# Altium schematic sheet main.SchDoc
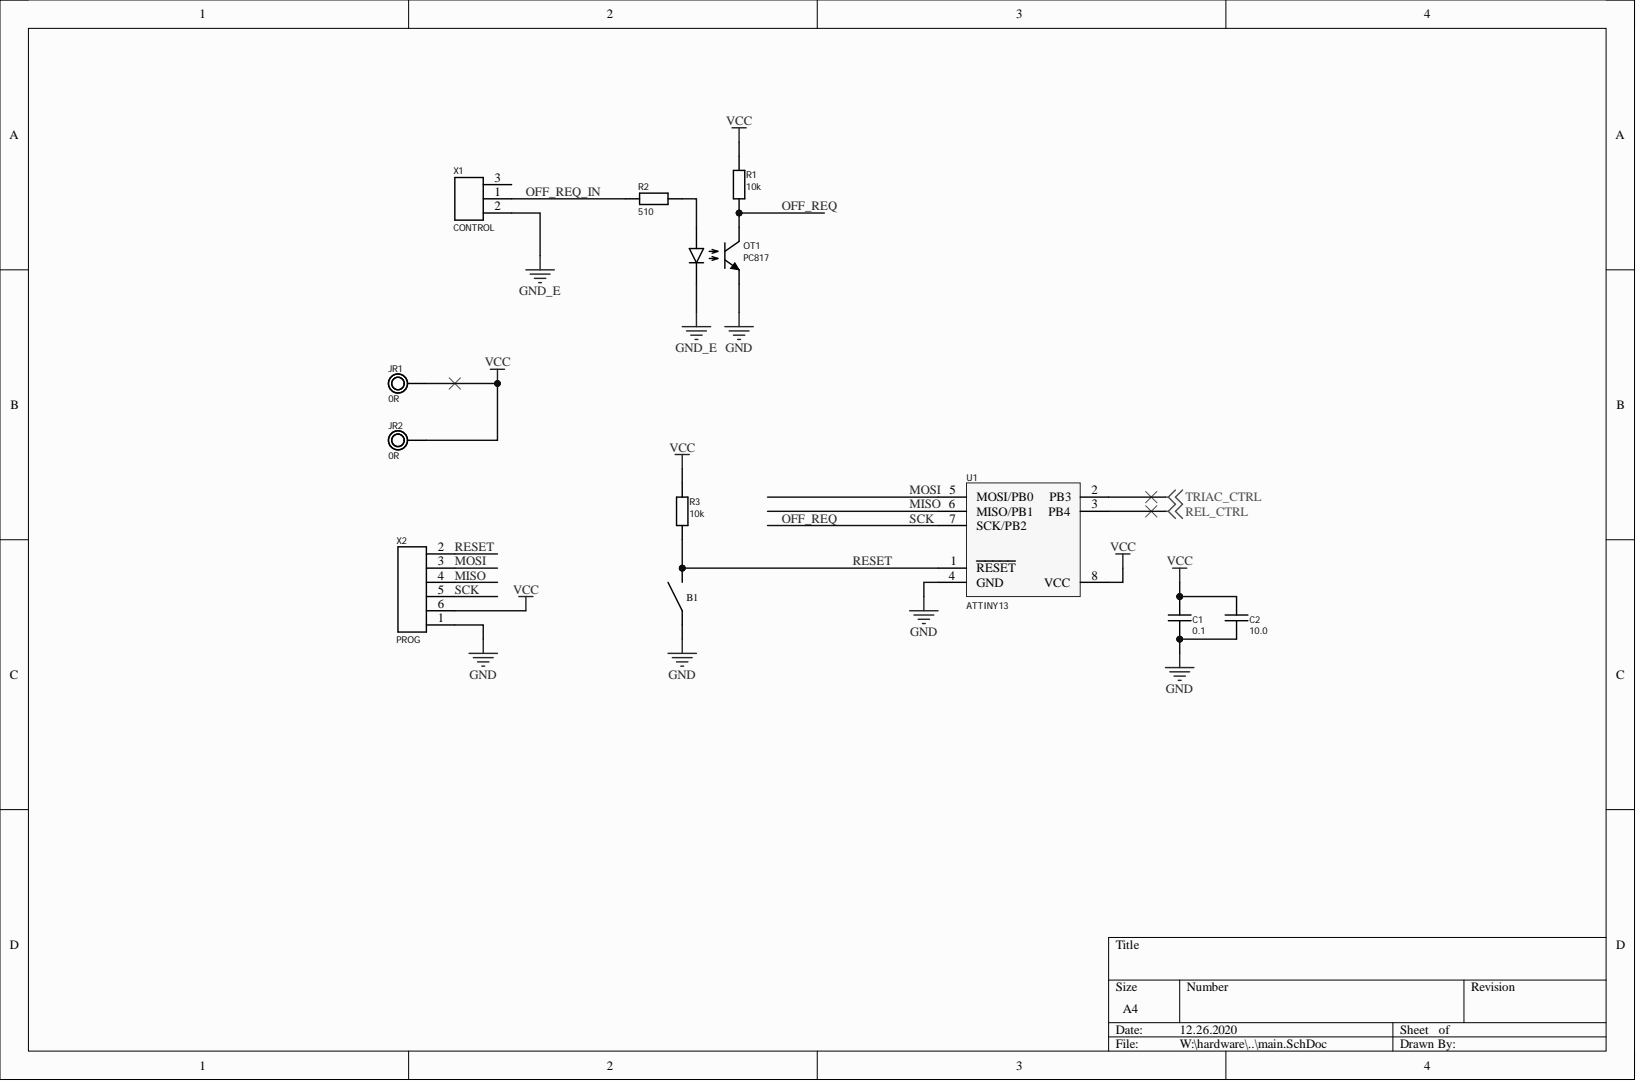
[diagram p0: main.SchDoc]

## Parts
B1  RESET  [VE144P05]  (value not drawn)
C1  0.1  [C-1206]
C2  10.0  [C-1206]
JR1  0R  [J-1206]
JR2  0R  [J-1206]
OT1  PC817  [SOP-4]
R1  10k  [R-0805]
R2  510  [R-0805]
R3  10k  [R-0805]
U1  ATTINY13  [SO-8]
X1  CONTROL  [PINHEAD-MALE-3x1]
X2  PROG  [PINHEAD-MALE-6x1]

## Nets
GND: B1.1 C1.2 C2.2 OT1.E U1.4(GND) X2.1
GND_E: OT1.LC X1.2
REL_CTRL: U1.3(PB4)
TRIAC_CTRL: U1.2(PB3)
VCC: C1.1 C2.1 JR1.1 JR2.1 R1.1 R3.2 U1.8(VCC) X2.6
MISO: U1.6(MISO/PB1) X2.4
MOSI: U1.5(MOSI/PB0) X2.3
OFF_REQ: OT1.C R1.2 U1.7(SCK/PB2) X2.5
OFF_REQ_IN: R2.2 X1.1
RESET: B1.2 R3.1 U1.1(RESET) X2.2
NetOT1_LA: OT1.LA R2.1

Not connected: X1 3

## Library data of the parts
B1 = BUTTON: VE144P05; Component Kind: Standard; Library Name: all-in-one.IntLib
C1 C2 = CAPACITOR: Component Kind: Standard; Library Name: all-in-one.IntLib
  C1: value: 0.1
  C2: value: 10.0
JR1 JR2 = JAMPER-RES: Component Kind: Standard; Library Name: all-in-one.IntLib; value: 0R
OT1 = OPT_PIC817: Component Kind: Standard; Library Name: all-in-one.IntLib; value: PC817
R1-R3 = RESISTOR: Component Kind: Standard; Library Name: all-in-one.IntLib
  R1 R3: value: 10k
  R2: value: 510
X1 = PINHEAD-3x1: Component Kind: Standard; Library Name: all-in-one.IntLib
X2 = PINHEAD-6x1: Component Kind: Standard; Library Name: all-in-one.IntLib
U1 = ATTINY13: Component Kind: Standard; Library Name: all-in-one.IntLib; value: ATTINY13
